# Altium schematic sheet W25Q128.SchDoc(W25Q128)
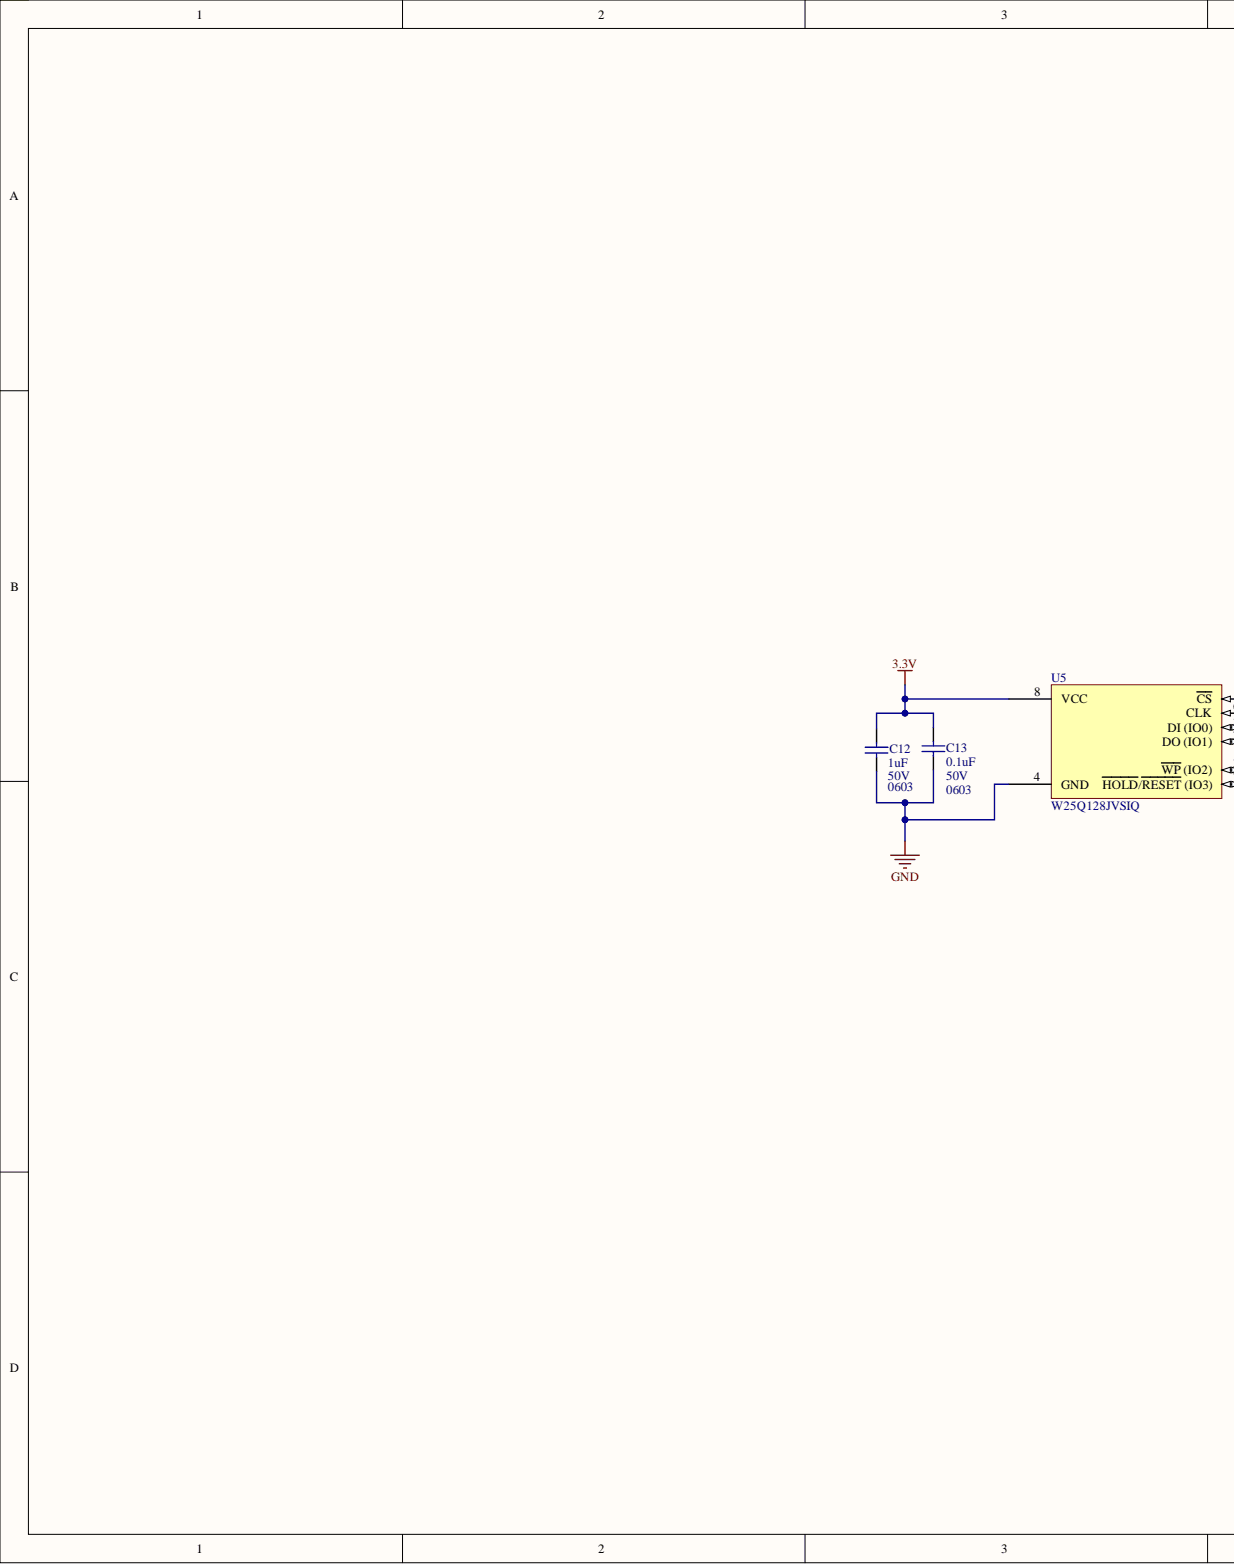
[diagram p0: W25Q128.SchDoc, left]
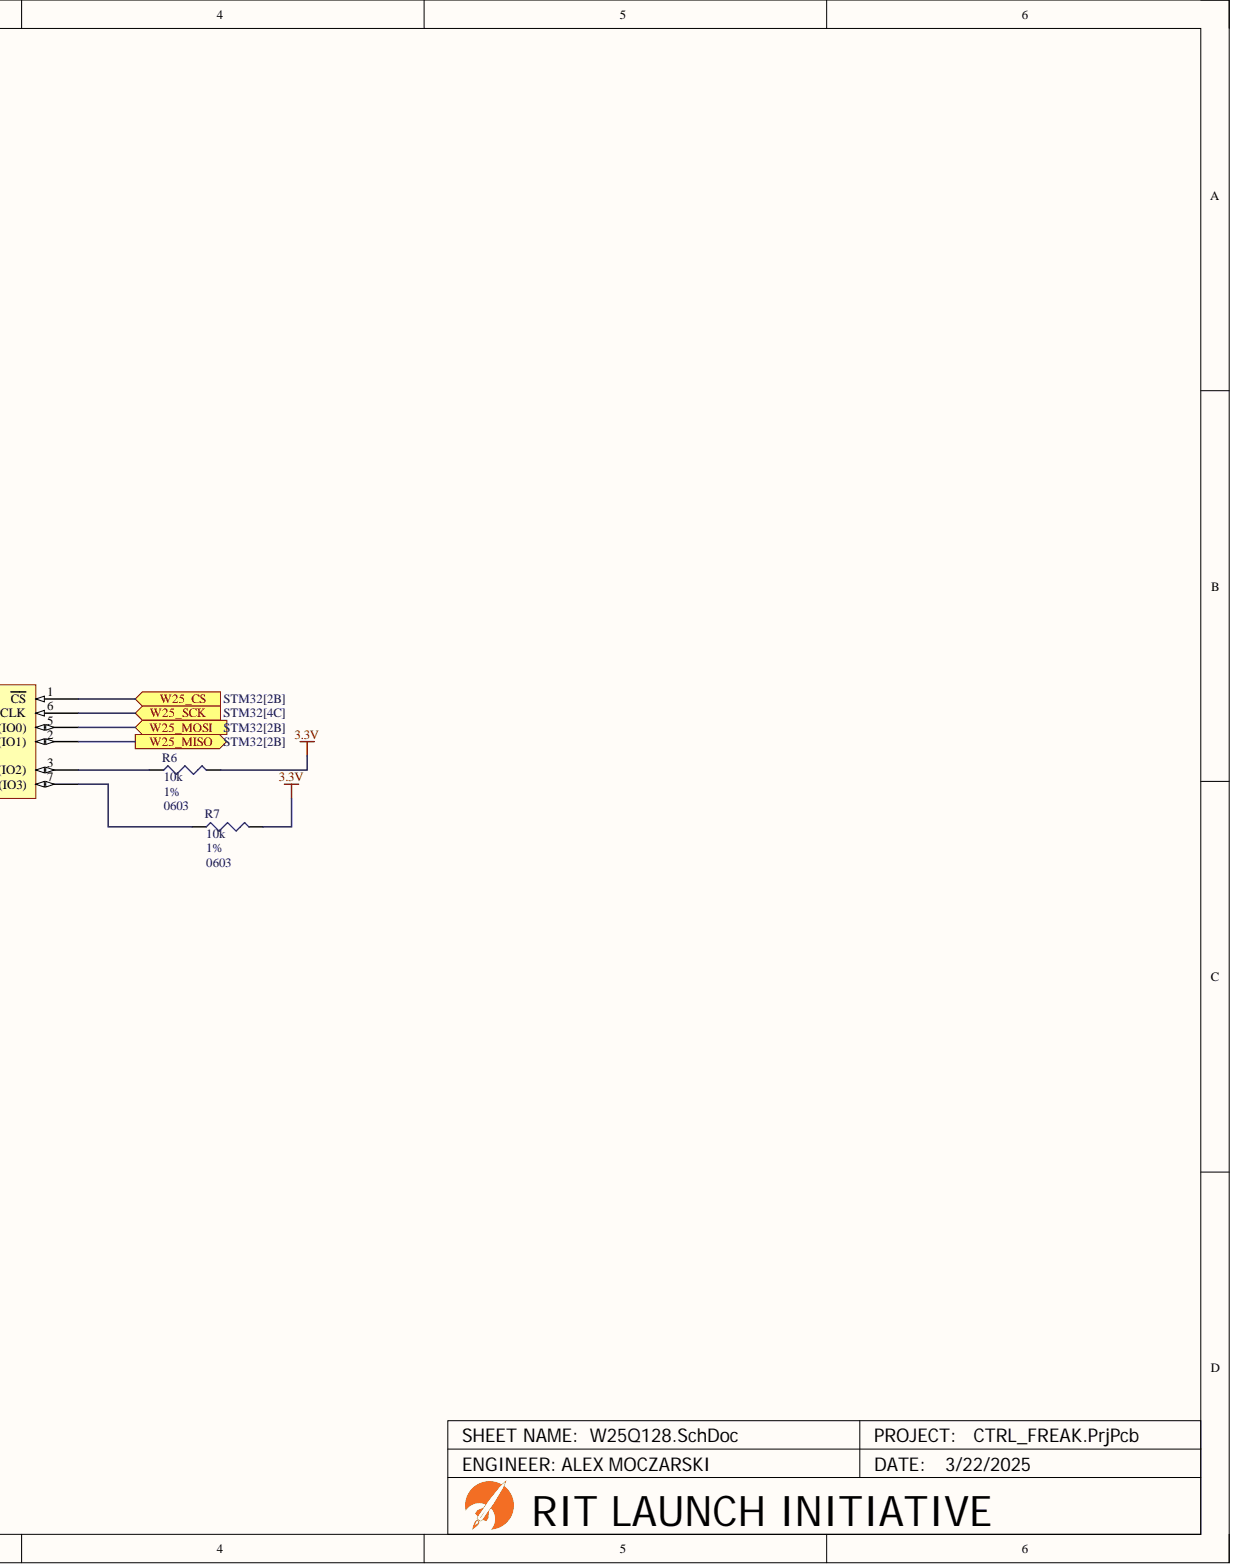
[diagram p1: W25Q128.SchDoc, right]

## Parts
C12  Capacitance: 1uF  Case Code (Imperial): 0603  Voltage: 50V  (hidden comment: CL10A105KB8NNNC)
C13  Capacitance: 0.1uF  Case Code (Imperial): 0603  Voltage: 50V  (hidden comment: CC0603KRX7R9BB104)
R6  Resistance: 10k  Tolerance: 1%  (hidden comment: 0603WAF1002T5E)
R7  Case/Package: 0603  Resistance: 10k  Tolerance: 1%  (hidden comment: 0603WAF1002T5E)
U5  W25Q128JVSIQ

## Ports
W25_CS, W25_MISO, W25_MOSI, W25_SCK

## Nets
3.3V: C12.2 C13.2 R6.2 R7.2 U5.8(VCC)
GND: C12.1 C13.1 U5.4(GND)
W25_CS: U5.1(CS) ; port W25_CS
W25_MISO: U5.2(DO_(IO1)) ; port W25_MISO
W25_MOSI: U5.5(DI_(IO0)) ; port W25_MOSI
W25_SCK: U5.6(CLK) ; port W25_SCK
NetR6_1: R6.1 U5.3(WP_(IO2))
NetR7_1: R7.1 U5.7(HOLD/RESET_(IO3))

## Library data of the parts
C12 = CMP-001-0307-1: CAP CER 1UF 50V X5R 0603; Tolerance: 10%; Case Code (Metric): 1608; Case/Package: 0603; Component Kind: Standard; Construction: Flat; Contact Plating: Tin; Depth: 0.8mm; Dielectric: X5R; JLCPCB Part #: C15849; Length: 1.6mm; Max Operating Temperature: 85°C; Min Operating Temperature: -55°C; Mounting Technology: Surface Mount; Package Quantity: 1; Packaging: Tape and Reel; Radiation Hardening: No; RoHS Compliant: Yes; Series: CL; Termination: Wraparound; Thickness: 0.035inch; Width: 0.031inch; Library Name: RIT Launch Initiative
C13 = CMP-001-0242-3: CAP CER 0.1UF 50V X7R 0603; Dielectric Material: Ceramic; Tolerance: 10%; Case Code (Metric): 1608; Case/Package: 0603; Component Kind: Standard; Depth: 0.8mm; Dielectric: X7R; Halogen Free: Halogen Free; Height: 0.8mm; JLCPCB Part #: C14663; Lead Free: Lead Free; Length: 0.063inch; Max Operating Temperature: 125°C; Min Operating Temperature: -55°C; Mount: Surface Mount; Number of Pins: 2; Package Quantity: 1; Packaging: Tape and Reel; Radiation Hardening: No; REACH SVHC: No SVHC; RoHS Compliant: Yes; Series: CC; Termination: SMD/SMT; Thickness: 0.035inch; Voltage Rating: 50V; Width: 0.031inch; Library Name: RIT Launch Initiative
R6 R7 = CMP-001-0268-1: RES 10K OHM 1% 1/10W 0603; Component Kind: Standard; JLCPCB Part #: C25804; RoHS Compliant: Yes; Library Name: RIT Launch Initiative
  R6: Case/Package: 0603
U5 = CMP-001-0257-8: NOR Flash Serial (SPI, Dual SPI, Quad SPI), 3.3V, 128M-bit, 8-Pin SOIC; Interface: SPI,Serial; Memory Type: Non-Volatile NOR FLASH; Operating Voltage: 2.7 to 3.6V; Case/Package: 8-Pin SOIC; Component Kind: Standard; JLCPCB Part #: C113767; Memory Size: 128M-bit; Operating Temperature Range: -40°C to 85°C; RoHS Compliant: Yes; Series: SpiFlash®; Library Name: RIT Launch Initiative
